# Altium schematic sheet PERIPHERAL_PORT_IO.SchDoc
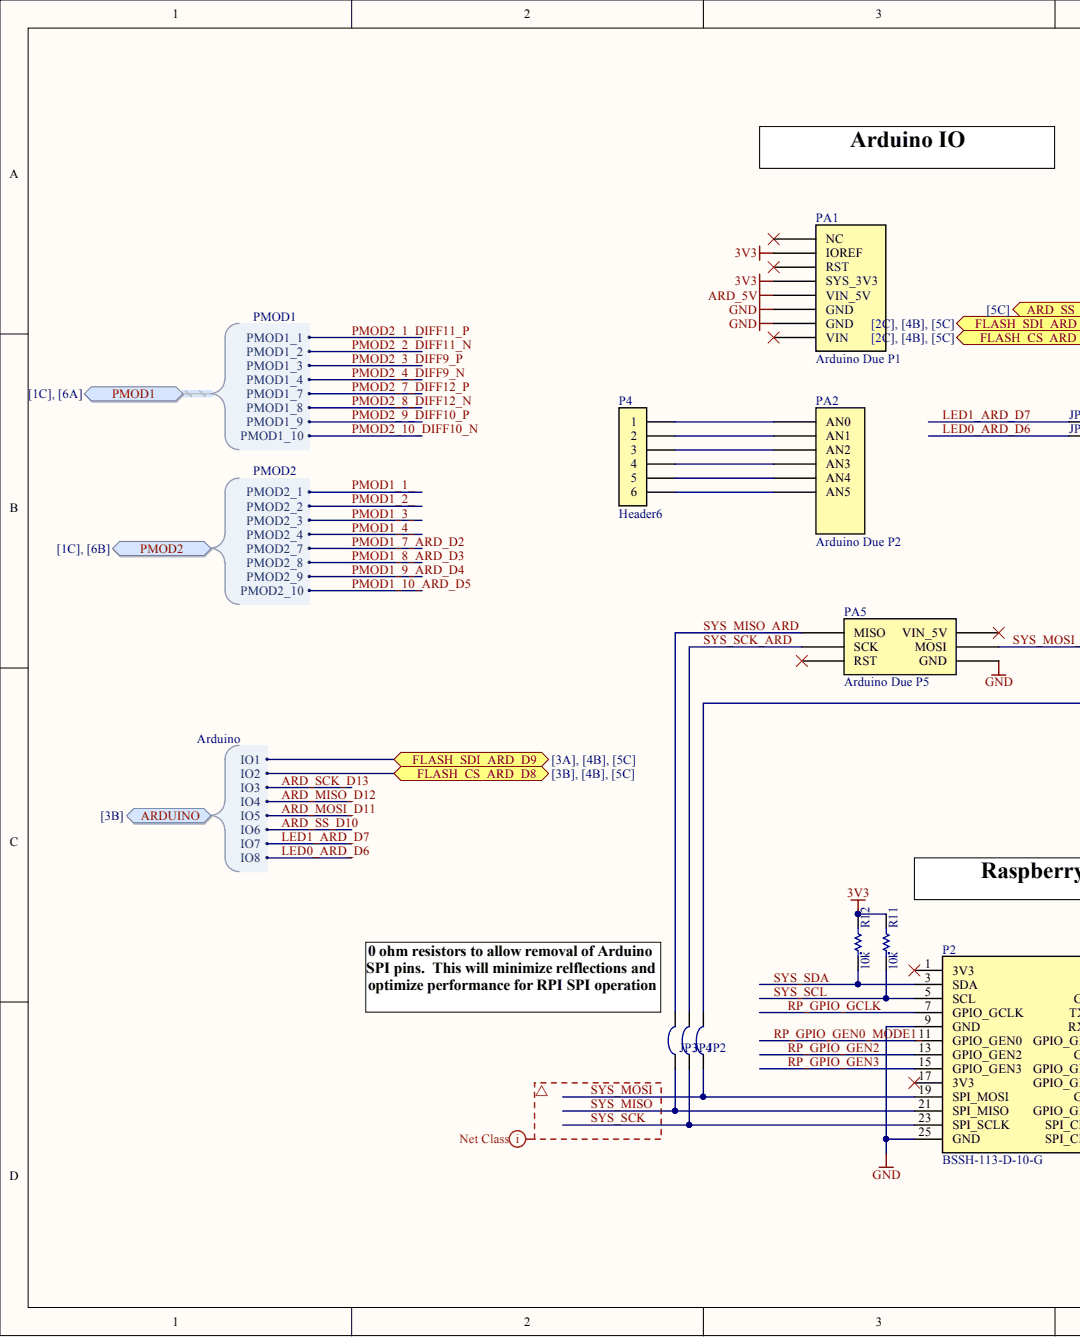
[diagram p0: PERIPHERAL_PORT_IO.SchDoc, left]
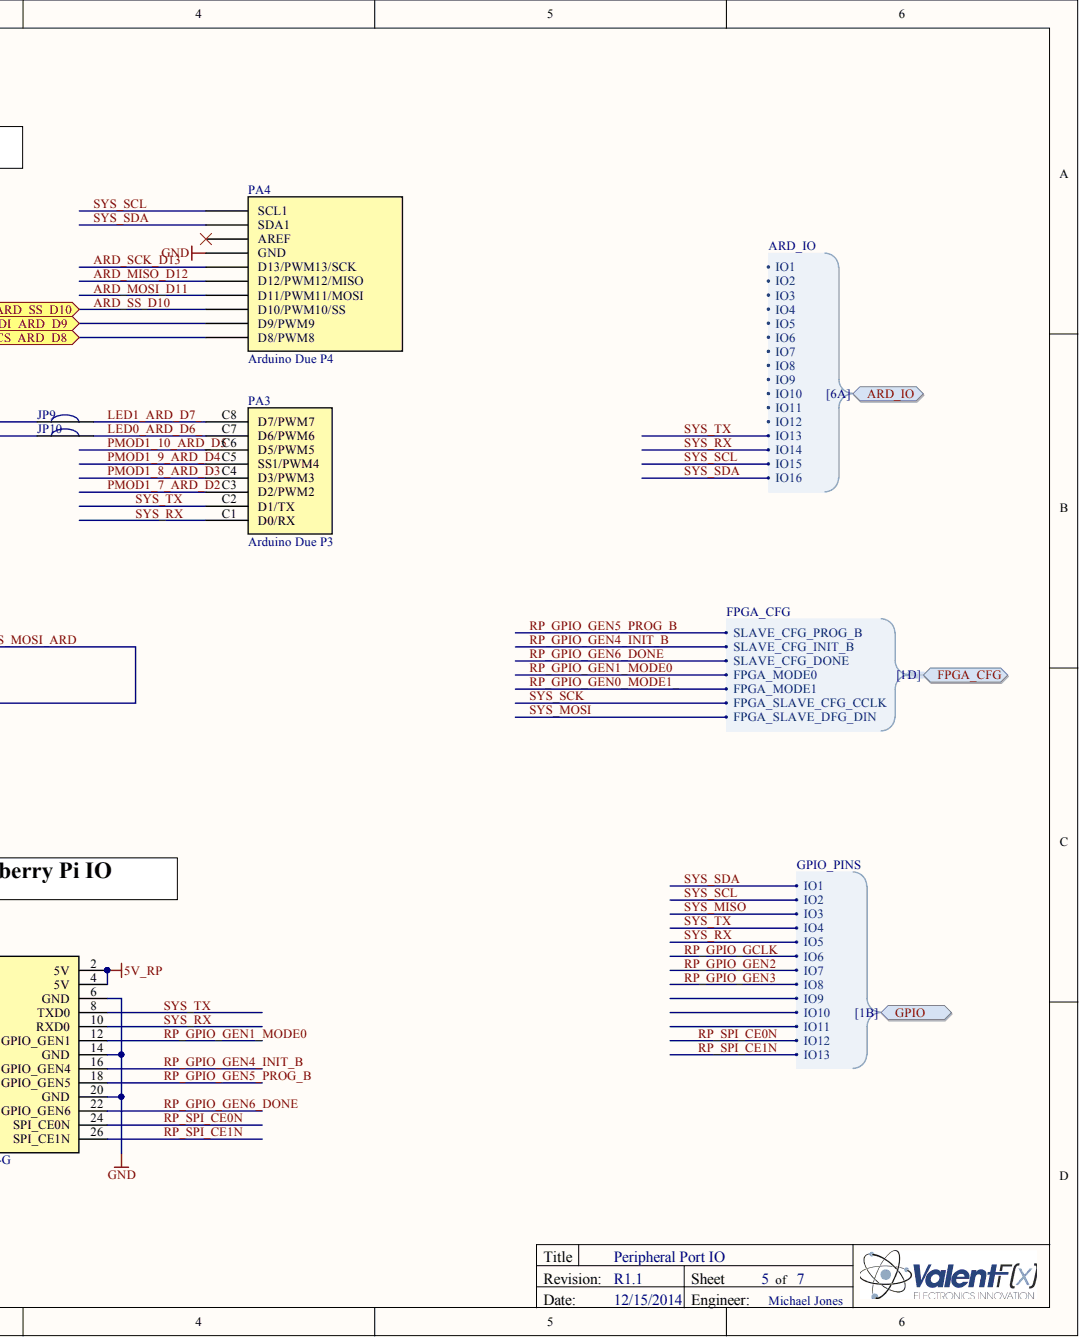
[diagram p1: PERIPHERAL_PORT_IO.SchDoc, right]

## Parts
JP2  Solder Jumper  [SOLDER_JUMPER]  (value not drawn)  (no BOM)
JP3  Solder Jumper  [SOLDER_JUMPER]  (value not drawn)  (no BOM)
JP4  Solder Jumper  [SOLDER_JUMPER]  (value not drawn)  (no BOM)
JP9  Solder Jumper-NC  [SOLDER_JUMPER-NC]  (value not drawn)
JP10  Solder Jumper-NC  [SOLDER_JUMPER-NC]  (value not drawn)
P2  BSSH-113-D-10-G  [Raspberr Pi - 26]
P4  Header6  [HEADER_6_40_100 THRU SIP]  (no BOM)
PA1  Arduino Due P1  [Arduino Due P1]
PA2  Arduino Due P2  [Arduino Due P2]
PA3  Arduino Due P3  [Arduino Due P3]
PA4  Arduino Due P4  [Arduino Due P4]
PA5  Arduino Due P5  [Arduino Due P5]  (no BOM)
R11  10k  [R0603]
R12  10k  [R0603]

## Ports
ARD_IO, ARD_IO.IO1, ARD_IO.IO2, ARD_IO.IO3, ARD_IO.IO4, ARD_IO.IO5, ARD_IO.IO6, ARD_IO.IO7, ARD_IO.IO8, ARD_IO.IO9, ARD_IO.IO10, ARD_IO.IO11, ARD_IO.IO12, ARD_IO.IO13, ARD_IO.IO14, ARD_IO.IO15, ARD_IO.IO16, ARD_SS_D10, ARDUINO, ARDUINO.IO1, ARDUINO.IO2, ARDUINO.IO3, ARDUINO.IO4, ARDUINO.IO5, ARDUINO.IO6, ARDUINO.IO7, ARDUINO.IO8, FLASH_CS_ARD_D8, FLASH_SDI_ARD_D9, FPGA_CFG, FPGA_CFG.FPGA_MODE0, FPGA_CFG.FPGA_MODE1, FPGA_CFG.FPGA_SLAVE_CFG_CCLK, FPGA_CFG.FPGA_SLAVE_DFG_DIN, FPGA_CFG.SLAVE_CFG_DONE, FPGA_CFG.SLAVE_CFG_INIT_B, FPGA_CFG.SLAVE_CFG_PROG_B, GPIO, GPIO.IO1, GPIO.IO2, GPIO.IO3, GPIO.IO4, GPIO.IO5, GPIO.IO6, GPIO.IO7, GPIO.IO8, GPIO.IO9, GPIO.IO10, GPIO.IO11, GPIO.IO12, GPIO.IO13, PMOD1, PMOD1.PMOD1_1, PMOD1.PMOD1_2, PMOD1.PMOD1_3, PMOD1.PMOD1_4, PMOD1.PMOD1_7, PMOD1.PMOD1_8, PMOD1.PMOD1_9, PMOD1.PMOD1_10, PMOD2, PMOD2.PMOD2_1, PMOD2.PMOD2_2, PMOD2.PMOD2_3, PMOD2.PMOD2_4, PMOD2.PMOD2_7, PMOD2.PMOD2_8, PMOD2.PMOD2_9, PMOD2.PMOD2_10

## Nets
3V3: PA1.A2(IOREF) PA1.A4(SYS_3V3) R11.2 R12.2
5V_RP: P2.2(5V) P2.4(5V)
ARD_5V: PA1.A5(VIN_5V)
GND: P2.6(GND) P2.9(GND) P2.14(GND) P2.20(GND) P2.25(GND) PA1.A6(GND) PA1.A7(GND) PA4.D7(GND) PA5.E6(GND)
ARD_MISO_D12: PA4.D5(D12/PWM12/MISO) ; port ARDUINO
ARD_MOSI_D11: PA4.D4(D11/PWM11/MOSI) ; port ARDUINO
ARD_SCK_D13: PA4.D6(D13/PWM13/SCK) ; port ARDUINO
ARD_SS_D10: PA4.D3(D10/PWM10/SS) ; port ARDUINO, port ARD_SS_D10
LED0_ARD_D6: JP10.1 ; port ARDUINO
LED0_ARD_D6_: JP10.2 PA3.C7(D6/PWM6)
LED1_ARD_D7: JP9.1 ; port ARDUINO
LED1_ARD_D7_: JP9.2 PA3.C8(D7/PWM7)
PMOD1_7_ARD_D2: PA3.C3(D2/PWM2) ; port PMOD2
PMOD1_8_ARD_D3: PA3.C4(D3/PWM3) ; port PMOD2
PMOD1_9_ARD_D4: PA3.C5(SS1/PWM4) ; port PMOD2
PMOD1_10_ARD_D5: PA3.C6(D5/PWM5) ; port PMOD2
RP_GPIO_GCLK: P2.7(GPIO_GCLK) ; port GPIO
RP_GPIO_GEN0_MODE1_: P2.11(GPIO_GEN0) ; port FPGA_CFG
RP_GPIO_GEN1_MODE0: P2.12(GPIO_GEN1) ; port FPGA_CFG
RP_GPIO_GEN2: P2.13(GPIO_GEN2) ; port GPIO
RP_GPIO_GEN3: P2.15(GPIO_GEN3) ; port GPIO
RP_GPIO_GEN4_INIT_B: P2.16(GPIO_GEN4) ; port FPGA_CFG
RP_GPIO_GEN5_PROG_B: P2.18(GPIO_GEN5) ; port FPGA_CFG
RP_GPIO_GEN6_DONE: P2.22(GPIO_GEN6) ; port FPGA_CFG
RP_SPI_CE0N: P2.24(SPI_CE0N) ; port GPIO
RP_SPI_CE1N: P2.26(SPI_CE1N) ; port GPIO
SYS_MISO: JP3.1 P2.21(SPI_MISO) ; port GPIO
SYS_MISO_ARD: JP3.2 PA5.E1(MISO)
SYS_MOSI: JP2.1 P2.19(SPI_MOSI) ; port FPGA_CFG
SYS_MOSI_ARD: JP2.2 PA5.E4(MOSI)
SYS_RX: P2.10(RXD0) PA3.C1(D0/RX) ; port ARD_IO, port GPIO
SYS_SCK: JP4.1 P2.23(SPI_SCLK) ; port FPGA_CFG
SYS_SCK_ARD: JP4.2 PA5.E3(SCK)
SYS_SCL: P2.5(SCL) PA4.D10(SCL1) R11.1 ; port ARD_IO, port GPIO
SYS_SDA: P2.3(SDA) PA4.D9(SDA1) R12.1 ; port ARD_IO, port GPIO
SYS_TX: P2.8(TXD0) PA3.C2(D1/TX) ; port ARD_IO, port GPIO
NetP4_1: P4.1 PA2.B1(AN0)
NetP4_2: P4.2 PA2.B2(AN1)
NetP4_3: P4.3 PA2.B3(AN2)
NetP4_4: P4.4 PA2.B4(AN3)
NetP4_5: P4.5 PA2.B5(AN4)
NetP4_6: P4.6 PA2.B6(AN5)
NetPA4_D1: PA4.D1(D8/PWM8) ; port ARDUINO, port FLASH_CS_ARD_D8
NetPA4_D2: PA4.D2(D9/PWM9) ; port ARDUINO, port FLASH_SDI_ARD_D9
N00220: ; port GPIO
N00221: ; port GPIO
N00222: ; port GPIO
N00223: ; port ARD_IO
N00224: ; port ARD_IO
N00225: ; port ARD_IO
N00226: ; port ARD_IO
N00227: ; port ARD_IO
N00228: ; port ARD_IO
N00229: ; port ARD_IO
N00230: ; port ARD_IO
N00231: ; port ARD_IO
N00232: ; port ARD_IO
N00233: ; port ARD_IO
N00234: ; port ARD_IO
N00235:
PMOD1_1_: ; port PMOD2
PMOD1_2_: ; port PMOD2
PMOD1_3_: ; port PMOD2
PMOD1_4_: ; port PMOD2
PMOD2_1_DIFF11_P: ; port PMOD1
PMOD2_2_DIFF11_N: ; port PMOD1
PMOD2_3_DIFF9_P: ; port PMOD1
PMOD2_4_DIFF9_N: ; port PMOD1
PMOD2_7_DIFF12_P: ; port PMOD1
PMOD2_8_DIFF12_N: ; port PMOD1
PMOD2_9_DIFF10_P: ; port PMOD1
PMOD2_10_DIFF10_N: ; port PMOD1

Not connected: P2 1(3V3) 17(3V3); PA1 A1(NC) A3(RST) A8(VIN); PA4 D8(AREF); PA5 E2(VIN_5V) E5(RST)

## Library data of the parts
JP2-JP4 = Solder Jumper: Solder/Configuration Jumper; Library Name: mConnector.SchLib; Manufacturer: n/a; Manufacturer Part Number: n/a; ROHS Certificate: n/a; Source: n/a; Source Part Number: n/a
JP9 JP10 = Solder Jumper-NC: Solder/Configuration Jumper; Component Kind: Net Tie; Library Name: mConnector.SchLib; Manufacturer: n/a; Manufacturer Part Number: n/a; ROHS Certificate: n/a; Source: n/a; Source Part Number: n/a
P2 = Raspberry Pi - 26: Manufacturer: Major League Electroncis; Component Kind: Standard; Datasheet: http://s3.amazonaws.com/mlkelly/productMedia/BSSH-1/BSSH-1-D-Datasheet.pdf; Description: Raspberry Pi - 26; Library Name: mConnector.SchLib; Manufacturer Part Number: BSSH-113-D-10-G; Price1: 1.95; Supplier 1: Adafruit; Supplier Part Number 1: BSSH-113-D-10-G; Value: BSSH-113-D-10-G
P4 = Header6: Header 6 pin; Library Name: mConnector.SchLib
PA1 = Arduino Due P1: CONN HEADER FEMALE 8POS .1" GOLD; Manufacturer: Sullins Connector Solutions; Manufacturer Part Number: PPPC081LFBN-RC; Number of Positions: 8; Number of Positions Loaded: All; Pitch: 0.100" (2.54mm); Category: Connectors, Interconnects; Color: Black; Component Kind: Standard; ComponentLink1Description: http://www.sullinscorp.com/drawings/78_P(N)PxCxxxLFBN-RC,_10492-G.pdf; ComponentLink1URL: http://www.sullinscorp.com/drawings/78_P(N)PxCxxxLFBN-RC,_10492-G.pdf; Connector Type: Header; Contact Finish: Gold; Contact Finish Thickness: Flash; Contact Type: Female Socket; Fastening Type: -; Features: -; Library Name: mConnector.SchLib; Mounting Type: Through Hole; Number of Rows: 1; Packaging: Bulk; Pricing 1: 1=0.89, 10=0.69, 25=0.6436, 50=0.585, 100=0.5265, 250=0.4446, 500=0.4212, 1000=0.3744, 2500=0.31122 (USD); RoHS: RoHS Compliant; Row Spacing: -; Stock 1: 30245; Supplier 1: Digi-Key; Supplier Part Number 1: S7041-ND; Termination: Solder
PA2 = Arduino Due P2: CONN HEADER FEMALE 6POS .1" GOLD; Manufacturer: Sullins Connector Solutions; Manufacturer Part Number: PPPC061LFBN-RC; Number of Positions: 6; Number of Positions Loaded: All; Pitch: 0.100" (2.54mm); Category: Connectors, Interconnects; Color: Black; Component Kind: Standard; ComponentLink1Description: http://www.sullinscorp.com/drawings/78_P(N)PxCxxxLFBN-RC,_10492-G.pdf; ComponentLink1URL: http://www.sullinscorp.com/drawings/78_P(N)PxCxxxLFBN-RC,_10492-G.pdf; Connector Type: Header; Contact Finish: Gold; Contact Finish Thickness: Flash; Contact Type: Female Socket; Fastening Type: -; Features: -; Library Name: mConnector.SchLib; Mounting Type: Through Hole; Number of Rows: 1; Packaging: Bulk; Pricing 1: 1=0.7, 10=0.546, 25=0.5088, 50=0.4626, 100=0.4163, 250=0.35152, 500=0.333, 1000=0.296, 2500=0.24605 (USD); RoHS: RoHS Compliant; Row Spacing: -; Stock 1: 9213; Supplier 1: Digi-Key; Supplier Part Number 1: S7039-ND; Termination: Solder
PA3 = Arduino Due P3: CONN HEADER FEMALE 8POS .1" GOLD; Manufacturer: Sullins Connector Solutions; Manufacturer Part Number: PPPC081LFBN-RC; Number of Positions: 8; Number of Positions Loaded: All; Pitch: 0.100" (2.54mm); Category: Connectors, Interconnects; Color: Black; Component Kind: Standard; ComponentLink1Description: http://www.sullinscorp.com/drawings/78_P(N)PxCxxxLFBN-RC,_10492-G.pdf; ComponentLink1URL: http://www.sullinscorp.com/drawings/78_P(N)PxCxxxLFBN-RC,_10492-G.pdf; Connector Type: Header; Contact Finish: Gold; Contact Finish Thickness: Flash; Contact Type: Female Socket; Fastening Type: -; Features: -; Library Name: mConnector.SchLib; Mounting Type: Through Hole; Number of Rows: 1; Packaging: Bulk; Pricing 1: 1=0.89, 10=0.69, 25=0.6436, 50=0.585, 100=0.5265, 250=0.4446, 500=0.4212, 1000=0.3744, 2500=0.31122 (USD); RoHS: RoHS Compliant; Row Spacing: -; Stock 1: 30245; Supplier 1: Digi-Key; Supplier Part Number 1: S7041-ND; Termination: Solder
PA4 = Arduino Due P4: CONN HEADER FMALE 10POS .1" GOLD; Manufacturer: Sullins Connector Solutions; Manufacturer Part Number: PPPC101LFBN-RC; Number of Positions: 10; Number of Positions Loaded: All; Pitch: 0.100" (2.54mm); Category: Connectors, Interconnects; Color: Black; Component Kind: Standard; ComponentLink1Description: http://www.sullinscorp.com/drawings/78_P(N)PxCxxxLFBN-RC,_10492-G.pdf; ComponentLink1URL: http://www.sullinscorp.com/drawings/78_P(N)PxCxxxLFBN-RC,_10492-G.pdf; Connector Type: Header; Contact Finish: Gold; Contact Finish Thickness: Flash; Contact Type: Female Socket; Fastening Type: -; Features: -; Library Name: mConnector.SchLib; Mounting Type: Through Hole; Number of Rows: 1; Packaging: Bulk; Pricing 1: 1=0.84, 10=0.699, 25=0.6476, 50=0.5828, 100=0.518, 250=0.45324, 500=0.4144, 1000=0.37555, 2500=0.3367 (USD); RoHS: RoHS Compliant; Row Spacing: -; Stock 1: 5570; Supplier 1: Digi-Key; Supplier Part Number 1: S7043-ND; Termination: Solder
R11 R12 = R0603 - CRCW060310K0FKEA: 10k Thick Film Resistors - SMD 1/10watt 10Kohms 1%; Manufacturer: Vishay Dale; Power (Watts): 0.1W, 1/10W; Temperature Coefficient: ±100ppm/°C; Category: Resistors; Component Kind: Standard; ComponentLink1Description: http://www.vishay.com/doc?20035; ComponentLink1URL: http://www.vishay.com/doc?20035; Composition: Thick Film; Features: -; Height: 0.020" (0.50mm); Library Name: mResistors.SchLib; Manufacturer Part Number: CRCW060310K0FKEA; Number of Terminations: 2; Package / Case: 0603 (1608 Metric); Packaging: Cut Tape (CT); Pricing 1: 10=0.081, 50=0.0436, 200=0.0251, 1000=0.01711 (USD); Resistance (Ohms): 10K; RoHS: RoHS Compliant; Size / Dimension: 0.061" L x 0.033" W (1.55mm x 0.85mm); Stock 1: 997856; Supplier 1: Digi-Key; Supplier Part Number 1: 541-10.0KHCT-ND; Tolerance: ±1%; Value: 10k
PA5 = Arduino Due P5: Library Name: mConnector.SchLib
